# Altium schematic sheet 1_RPi.SchDoc(1_RPi)
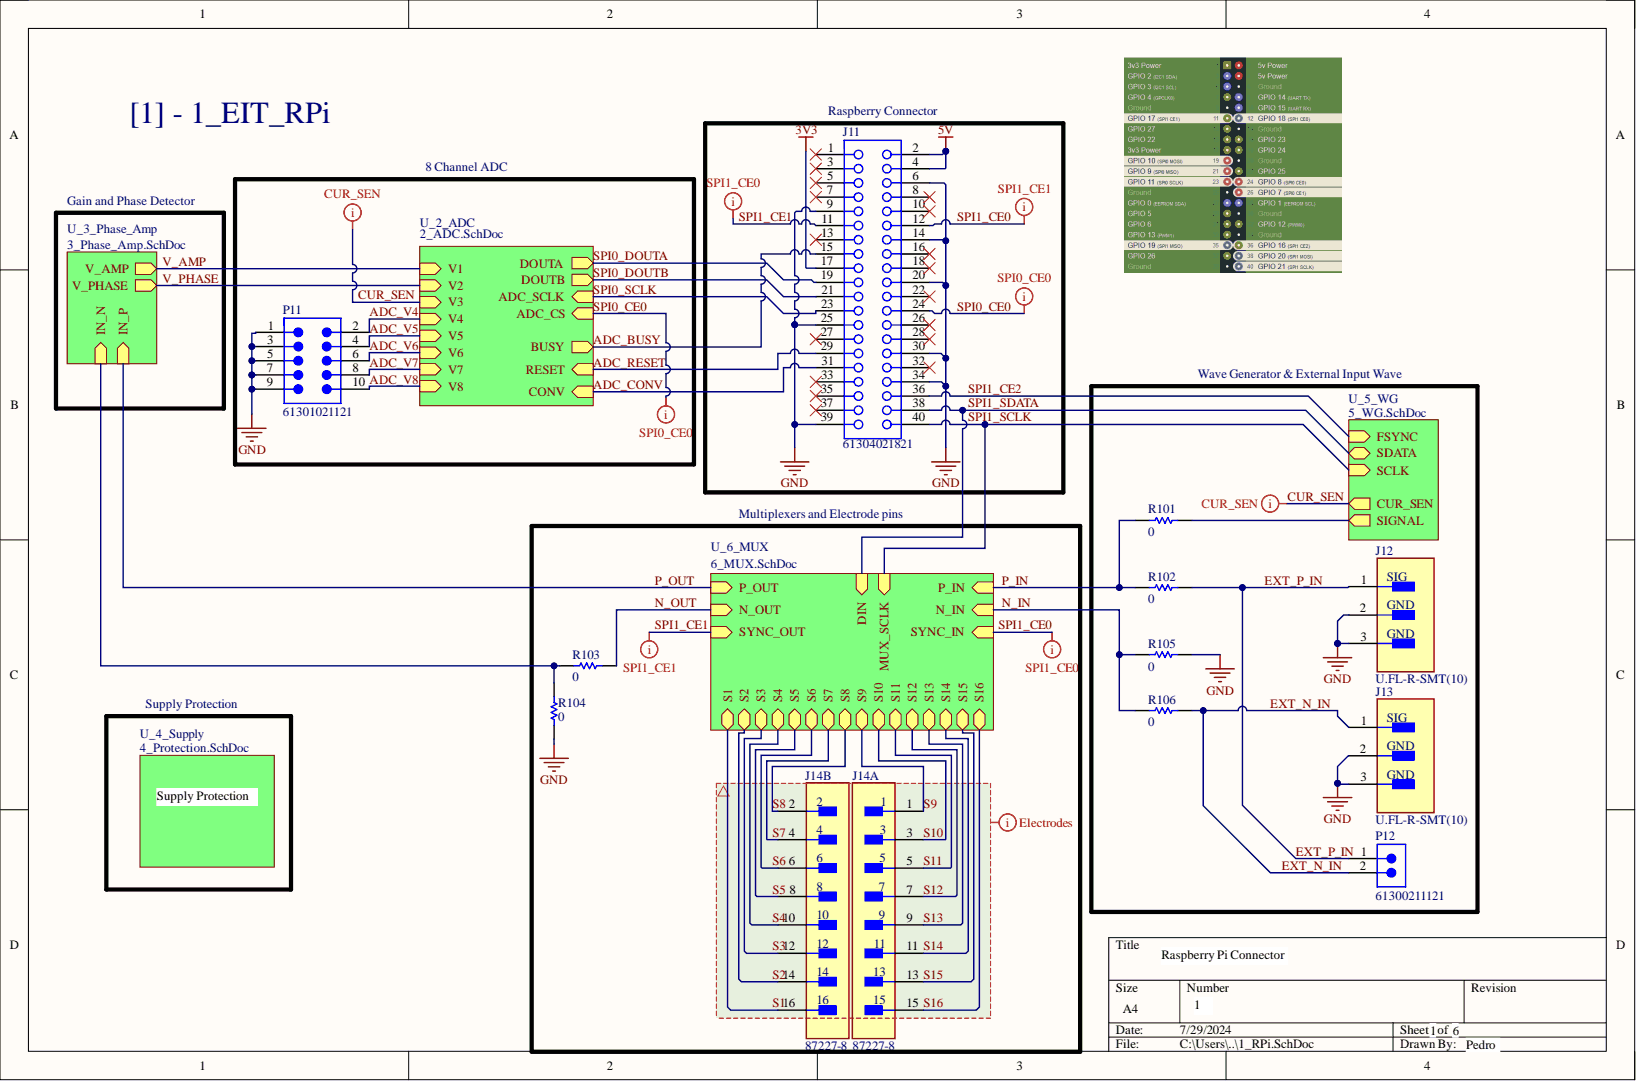
[diagram p0: 1_RPi.SchDoc]

## Parts
J11  61304021821  [61304021821]
J12  U.FL-R-SMT(10)  [FP-U_FL-R-SMT_10-MFG]
J13  U.FL-R-SMT(10)  [FP-U_FL-R-SMT_10-MFG]
J14  87227-8  [FP-87227-8-MFG]  (parts A,B)
P11  61301021121  [61301021121]
P12  61300211121  [61300211121]
R101  RC0603JR-070RL  [RESC1608X55X25LL10T15]  (value not drawn)
R102  RC0603JR-070RL  [RESC1608X55X25LL10T15]  (value not drawn)
R103  RC0603JR-070RL  [RESC1608X55X25LL10T15]  (value not drawn)
R104  RC0603JR-070RL  [RESC1608X55X25LL10T15]  (value not drawn)
R105  RC0603JR-070RL  [RESC1608X55X25LL10T15]  (value not drawn)
R106  RC0603JR-070RL  [RESC1608X55X25LL10T15]  (value not drawn)

## Nets
3V3: J11.17
5V: J11.2 J11.4
GND: J11.6 J11.9 J11.14 J11.20 J11.25 J11.30 J11.34 J11.39 J12.2 J12.3 J13.2 J13.3 P11.1 P11.3 P11.5 P11.7 P11.9 R104.2 R105.1
ADC_BUSY: J11.15
ADC_CONV: J11.31
ADC_RESET: J11.29
ADC_V4: P11.2
ADC_V5: P11.4
ADC_V6: P11.6
ADC_V7: P11.8
ADC_V8: P11.10
EXT_N_IN: J13.1 P12.2 R106.1
EXT_P_IN: J12.1 P12.1 R102.1
N_IN: R105.2 R106.2
N_OUT: R103.1
P_IN: R101.2 R102.2
S1: J14.16
S2: J14.14
S3: J14.12
S4: J14.10
S5: J14.8
S6: J14.6
S7: J14.4
S8: J14.2
S9: J14.1
S10: J14.3
S11: J14.5
S12: J14.7
S13: J14.9
S14: J14.11
S15: J14.13
S16: J14.15
SPI0_CE0: J11.24
SPI0_DOUTA: J11.19
SPI0_DOUTB: J11.21
SPI0_SCLK: J11.23
SPI1_CE0: J11.12
SPI1_CE1: J11.11
SPI1_CE2: J11.36
SPI1_SCLK: J11.40
SPI1_SDATA: J11.38
NetJ11_1: J11.1
NetJ11_3: J11.3
NetJ11_5: J11.5
NetJ11_7: J11.7
NetJ11_8: J11.8
NetJ11_10: J11.10
NetJ11_13: J11.13
NetJ11_16: J11.16
NetJ11_18: J11.18
NetJ11_22: J11.22
NetJ11_26: J11.26
NetJ11_27: J11.27
NetJ11_28: J11.28
NetJ11_32: J11.32
NetJ11_33: J11.33
NetJ11_35: J11.35
NetJ11_37: J11.37
NetR101_1: R101.1
NetR103_2: R103.2 R104.1
CUR_SEN:
P_OUT:
V_AMP:
V_PHASE:

## Library data of the parts
J11 = CMP-1502-01370-3: NexarPartId: 61924769; Contact Resistance: 20mΩ; Current Rating: 3A; Gender: Female; Operating Supply Voltage: 250V; Component Kind: Standard; Lead Pitch: 2.54mm; Library Name: Altium Content Vault; Number of Pins: 40; Orientation: Vertical; RoHS: Non-Compliant
J12 J13 = eef6fde44c591823e8076210edfe12f: CONN U.FL RCPT STR 50 OHM SMD; Body Material: Bronze; Body Plating: Silver; Connector Type: Coaxial; Dielectric Material: Polymer; Frequency: 6GHz; Impedance: 50R; Case/Package: SMD/SMT; Component Kind: Standard; Contact Material: Brass; Contact Plating: Gold; Depth: 3.1mm; Fastening Type: Snap; Housing Color: Beige; Lead Free: Lead Free; Length: 3mm; Max Frequency: 6GHz; Max Operating Temperature: 90°C; Min Operating Temperature: -40°C; Mount: Surface Mount; Orientation: Vertical; Package Quantity: 1; Packaging: Tape and Reel; Plating: Silver; Radiation Hardening: No; Termination: Solder; Library Name: RPI_EIT_SchLib.SchLib
J14 = CMP-03369-000064-1: CONN HEADER VERT 16POS 2.54MM; Manufacturer: TE Connectivity; Conn Gender: Male; Conn Orientation: Straight; Current Rating: 3A; Number Of Contacts: 16; Automotive: No; Category: Conn; Component Kind: Standard; ComponentLink2Description: Datasheet; ComponentLink2URL: https://www.te.com/usa-en/product-87227-8.datasheet.pdf; Contact Material: Gold,Nickel; Device Class L1: Connectors; Device Class L2: Headers and Wire Housings; Device Class L3: unset; Digikey Description: CONN HEADER VERT 16POS 2.54MM; Footprint Url: https://www.te.com/commerce/DocumentDelivery/DDEController?Action=showdoc&DocId=Customer+Drawing%7F87227%7FH4%7Fpdf%7FEnglish%7FENG_CD_87227_H4.pdf%7F87227-8; Height: 0.428inch; Lead Free: Yes; Library Name: Altium Content Vault; Manufacturer Part Number: 87227-8; Mouser Description: Headers & Wire Housings UNSHRD VERT DUAL 16; Number Of Rows: 2; Octopart: https://octopart.com/87227-8-te+connectivity-39407754; Package: 0; Pitch: 2.54mm; RoHS: TRUE; Temperature: -65°C to +105°C; Temperature Range High: +105°C; Temperature Range Low: -65°C
P11 = Header_Male_2R_10P: NexarPartId: 18818163; Connector Type: Male Pin; Contact Resistance: 20mΩ; Current Rating: 3A; Gender: Male; Insulation: Insulated; Insulation Resistance: 1GΩ; Number of Contacts: 10; Operating Supply Voltage: 250V; Pitch: 2.54mm; Color: Black; Component Kind: Standard; Contact Gender: Male; Contact Material: Copper; Contact Mating Length: 5.9944mm; Contact Plating: Gold; Flammability Rating: UL94 V-0; Height: 2.54mm; Housing Material: PBT; Lead Pitch: 2.54mm; Length: 12.7mm; Max Operating Temperature: 105°C; Min Operating Temperature: -40°C; Mount: Through Hole; Number of Pins: 10; Number of Positions: 10; Number of Rows: 2; Orientation: Vertical; Packaging: Bulk; REACH SVHC: Unknown; RoHS: Compliant; Row Spacing: 2.54mm; Termination: Through Hole; Voltage Rating: 250V; Width: 12.7mm; Library Name: RPI_EIT_SchLib.SchLib
P12 = CMP-1712-00002-6: NexarPartId: 20081794; Connector Type: Male Pin; Contact Resistance: 20mΩ; Current Rating: 3A; Gender: Male; Number of Contacts: 2; Operating Supply Voltage: 250V; Pitch: 2.54mm; Color: Black; Component Kind: Standard; Contact Gender: Male; Contact Material: Copper; Contact Mating Length: 5.9944mm; Contact Plating: Gold; Height: 8.94mm; Housing Material: PBT; Lead Free: Lead Free; Lead Pitch: 2.54mm; Library Name: Altium Content Vault; Max Operating Temperature: 125°C; Min Operating Temperature: -40°C; Mount: Through Hole; Number of Pins: 2; Number of Positions: 2; Number of Rows: 1; Orientation: Vertical; Packaging: Bulk; REACH SVHC: No SVHC; RoHS: Compliant; Termination: Through Hole; Voltage Rating: 250V
R101-R106 = RES-2: Chip Resistor, 0 Ohm, +/- 5%, 0.1 W, -55 to 155 degC, 0603 (1608 Metric), RoHS, Tape and Reel; Manufacturer: Yageo; Power Rating: 100mW; Resistance: 0; Temperature Coefficient: 200ppm/degreesC; Voltage Rating: 50V; Case Code (Imperial): 0603; Case Code (Metric): 1608; Case/Package: 0603; Component Kind: Standard; Composition: ThickFilm; Contact Plating: Tin; Datasheet URL: http://www.yageo.com/NewPortal/yageodocoutput?fileName=/pdf/R-Chip/PYu-RC_Group_51_RoHS_L_5.pdf; Datasheet Version: Rev. 5, 10/2016; Depth: 0.8mm; Height: 0.45mm; Lead Free: LeadFree; Length: 1.6mm; Manufacturer URL: http://www.yageo.com/; Max Operating Temperature: 155degC; Military Standard: Not; Min Operating Temperature: -55degC; Number of Pins: 2; Package Description: 2-Pin Surface Mount Device, Body 1.6 x 0.8 mm; Package Quantity: 5000; Package Reference: 0603; Packaging: TapeandReel; Radiation Hardening: No; REACH SVHC: NoSVHC; RoHSCompliant: true; Termination: SMD/SMT; Tolerance: 5%; Voltage Rating (DC): 50V; Width: 0.8mm; Library Name: RPI_EIT_SchLib.SchLib
